# Altium schematic sheet power.SchDoc(power)
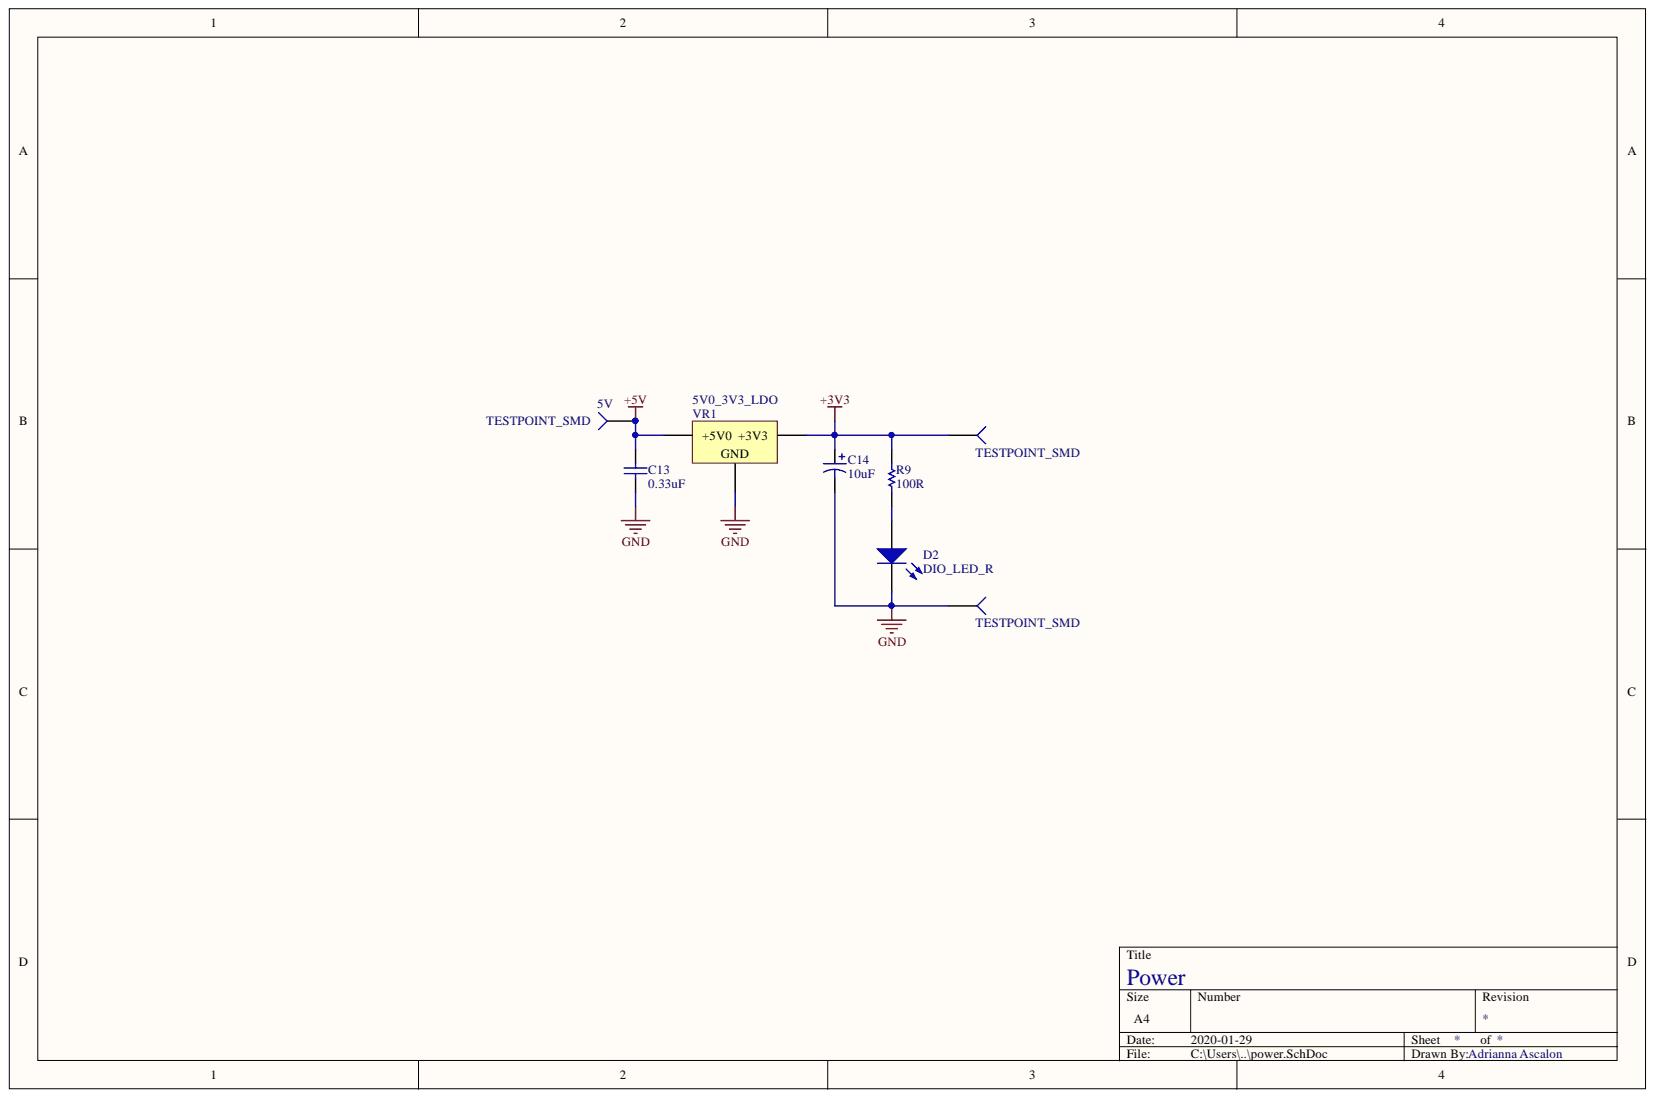
[diagram p0: power.SchDoc]

## Parts
3V3  TESTPOINT_SMD  [TESTPOINT]
5V  TESTPOINT_SMD  [TESTPOINT]
C13  value 0.33uF  [CAPC0603(1608)55_N]  (hidden comment: CAP_0.33uF_16V_0603)
C14  value 10uF  [AVX-CAPC3528_V]  (hidden comment: CAP_10uF_1411_POL)
D2  DIO_LED_R  [LED_0805_LINE]
GND  TESTPOINT_SMD  [TESTPOINT]
R9  value 100R  [RESC0603(1608)_N]  (hidden comment: RES_100R_0603)
VR1  5V0_3V3_LDO  [VOLTAGE_REGULATOR]

## Nets
+3V3: 3V3.1 C14.1 R9.2 VR1.2
+5V: 5V.1 C13.2 VR1.3
GND: C13.1 C14.2 D2.2 GND.1 VR1.1
NetD2_1: D2.1 R9.1

## Library data of the parts
C13 = CAP_0.33uF_16V_0603: CAP CER 0.33UF 16V X7R 0603; MPN: GCM188R71C334KA37D; Manufacturer: Murata Electronics North America; Voltage Rating: 16V; Temperature Coefficient: X7R; Simulation: CAP; Signal Integrity: Cap; Package: 0603 (1608 Metric); Dimensions: 0.063" L x 0.031" W (1.60mm x 0.80mm); Digikey Part Number: 490-6050-1-ND; Component Kind: Standard; Library Name: UWRT_Schematic_Library_2019.SchLib
C14 = CAP_10uF_1411_POL: CAP TANT 10UF 20% 10V 1411; MPN: F971A106MBAHT3; Manufacturer: AVX Corporation; Voltage Rating: 10V; Simulation: CAP; Signal Integrity: Cap; Package: 1411 (3528 Metric); Dimensions: 0.063" L x 0.031" W (1.60mm x 0.80mm); Digikey Part Number: 478-12047-1-ND; Component Kind: Standard; Library Name: UWRT_Schematic_Library_2019.SchLib
D2 = DIO_LED_R: LED RED DIFFUSED 0805 SMD; MPN: LS R976-NR-1; Manufacturer: OSRAM; Forward Voltage Drop: 2V; Simulation: LED1; Package: 0805 (2012 Metric); Digikey Part Number: 475-1278-1-ND; Component Kind: Standard; Library Name: UWRT_Schematic_Library_2019.SchLib
R9 = RES_100R_0603: RES SMD 100 OHM 1% 1/10W 0603; MPN: RC0603FR-07100RL; Manufacturer: Yageo; Tolerance: 1%; Simulation: RESISTOR; Signal Integrity: Res; Package: 0603 (1608 Metric); Dimensions: 0.063" L x 0.031" W (1.60mm x 0.80mm); Digikey Part Number: 311-100HRCT-ND; Component Kind: Standard; Library Name: UWRT_Schematic_Library_2019.SchLib
VR1 = 5V0_3V3_LDO: IC REG LINEAR 3.3V 1A DPAK; MPN: NCP1117DT33T5G; Manufacturer: ON Semiconductor; Digikey Part Number: NCP1117DT33T5GOSCT-ND; Current Output: 1A; Component Kind: Standard; Library Name: UWRT_Schematic_Library_2019.SchLib
3V3 5V GND = TESTPOINT_SMD: Component Kind: Standard; Library Name: UWRT_Schematic_Library_2019.SchLib
